# Altium schematic sheet Single_Supply_ADC_V1.1.SchDoc(Single_Supply_ADC_V1.1)
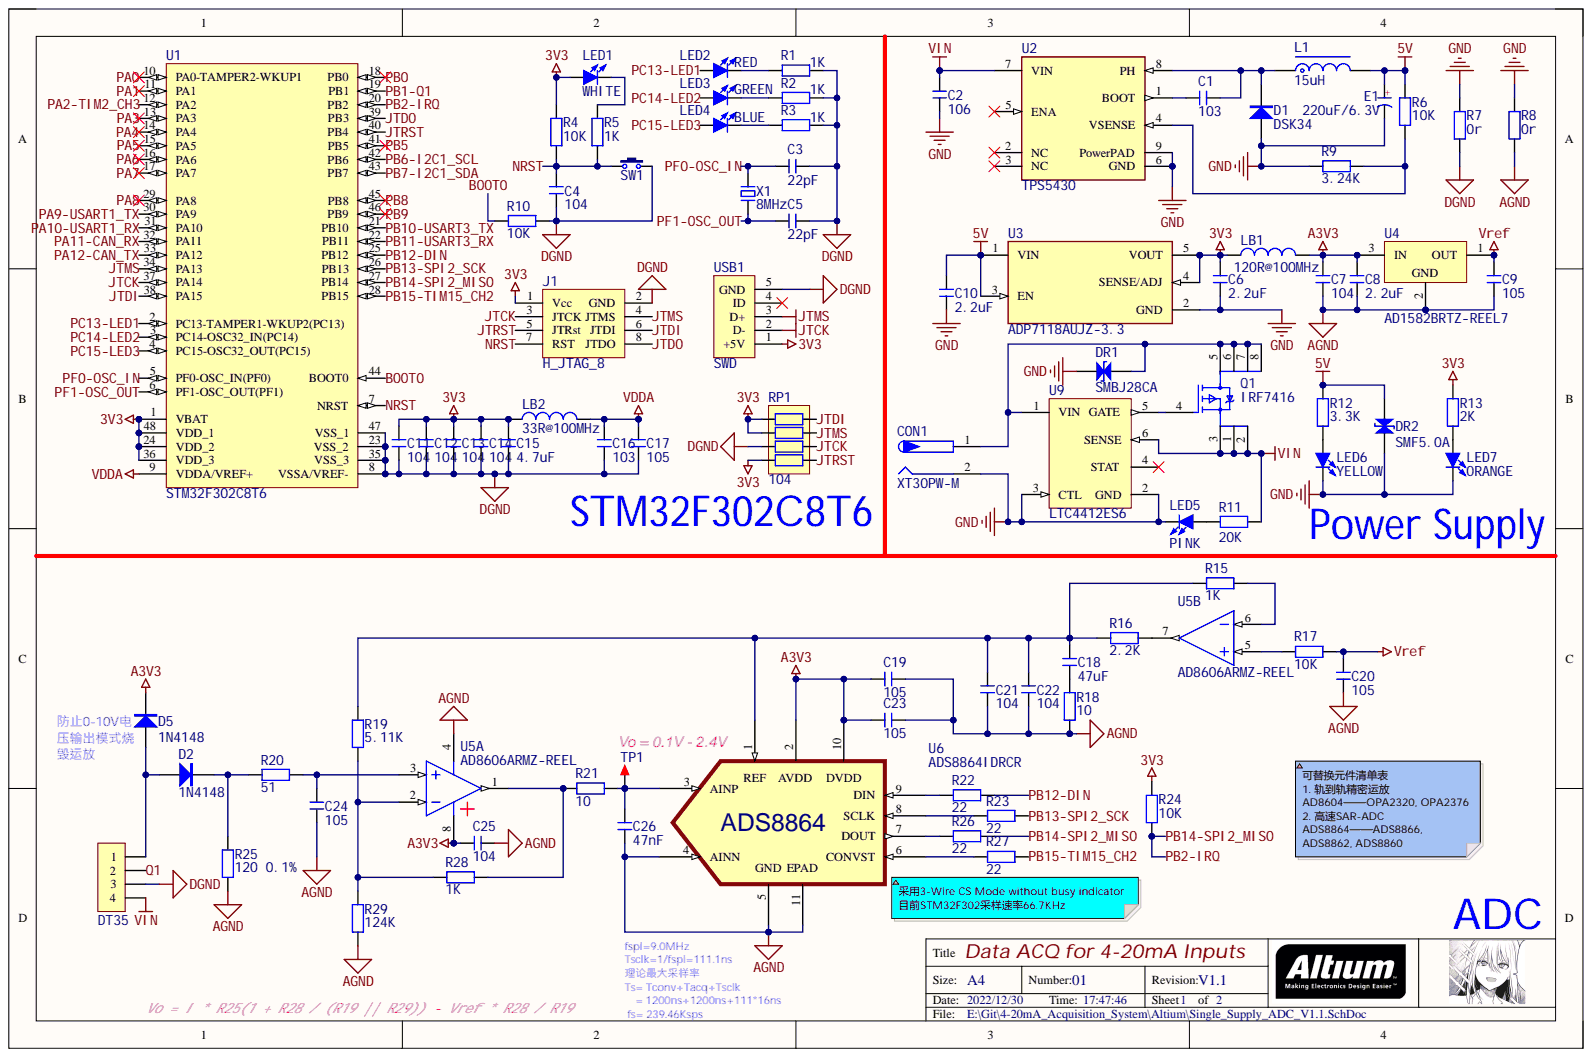
[diagram p0: Single_Supply_ADC_V1.1.SchDoc]

## Parts
C1  103  [C 0603_L]
C2  106  [C 0805_L]
C3  22pF  [C 0603_L]
C4  104  [C 0603_L]
C5  22pF  [C 0603_L]
C6  2.2uF  [C 0603_L]
C7  104  [C 0603_L]
C8  2.2uF  [C 0603_L]
C9  105  [C 0603_L]
C10  2.2uF  [C 0603_L]
C11  104  [C 0603_L]
C12  104  [C 0603_L]
C13  104  [C 0603_L]
C14  104  [C 0603_L]
C15  4.7uF  [C 0603_L]
C16  103  [C 0603_L]
C17  105  [C 0603_L]
C18  47uF  [C 0603_L]
C19  105  [C 0603_L]
C20  105  [C 0603_L]
C21  104  [C 0603_L]
C22  104  [C 0603_L]
C23  105  [C 0603_L]
C24  105  [C 0603_L]
C25  104  [C 0603_L]
C26  47nF  [C 0603_L]
CON1  XT30PW-M  [XT30PW-M]
D1  DSK34  [SOD-123]
D2  1N4148  [SOD-123]
D5  1N4148  [SOD-123]
DR1  SMBJ28CA  [SMB]
DR2  SMF5.0A  [SOD-323]
DT35  HDR-1X4  [XH2.54-LS-4P]  (value not drawn)
E1  220uF/6.3V  [CD B(3528)]
J1  H_JTAG_8  [IDC2.54-LI-2x4P]
L1  15uH  [NR4020]
LB1  120R@100MHz  [FB 0603_L]
LB2  33R@100MHz  [FB 0603_L]
LED1  WHITE  [LED 0603W]
LED2  RED  [LED 0603R]
LED3  GREEN  [LED 0603G]
LED4  BLUE  [LED 0603B]
LED5  PINK  [LED 0603R]
LED6  YELLOW  [LED 0603R]
LED7  ORANGE  [LED 0603R]
Q1  IRF7416  [SOP8_L]
R1  1K  [R 0603_L]
R2  1K  [R 0603_L]
R3  1K  [R 0603_L]
R4  10K  [R 0603_L]
R5  1K  [R 0603_L]
R6  10K  [R 0603_L]
R7  0r  [R 0603_L]
R8  0r  [R 0603_L]
R9  3.24K  [R 0603_L]
R10  10K  [R 0603_L]
R11  20K  [R 0603_L]
R12  3.3K  [R 0603_L]
R13  2K  [R 0603_L]
R15  1K  [R 0603_L]
R16  2.2K  [R 0603_L]
R17  10K  [R 0603_L]
R18  10  [R 0603_L]
R19  5.11K  [R 0603_L]
R20  51  [R 0603_L]
R21  10  [R 0603_L]
R22  22  [R 0603_L]
R23  22  [R 0603_L]
R24  10K  [R 0603_L]
R25  120 0.1%  [R 0603_L]
R26  22  [R 0603_L]
R27  22  [R 0603_L]
R28  1K  [R 0603_L]
R29  124K  [R 0603_L]
RP1  104  [RCA-8P4R-0603]
SW1  6x6x5  [TS 2PIN 6x6x4.8]  (value not drawn)
TP1  (no value)  [TEST 1]
U1  STM32F302C8T6  [LQFP48 7X7_L]
U2  TPS5430  [Texas_Instruments_DDA0008J_IPC_C]
U3  ADP7118AUJZ-3.3  [Analog_Devices_Inc_UJ-5_IPC_C]
U4  AD1582BRTZ-REEL7  [SOT23-3L]
U5  AD8606ARMZ-REEL  [RM_8_ADI-L]  (parts A,B)
U6  ADS8864IDRCR  [DRC10_TEX]
U9  LTC4412ES6  [Texas_Instruments_DDC0006A_0]
USB1  SWD  [USB-MICRO_C]
X1  8MHz  [OSC 5032-2P]

## Nets
3V3: C6.1 C11.2 C12.2 C13.2 C14.2 C15.2 J1.1(Vcc) LB1.1 LB2.1 LED1.1 R4.2 R13.2 R24.2 RP1.5 RP1.6 RP1.8 U1.1(VBAT) U1.24(VDD_2) U1.36(VDD_3) U1.48(VDD_1) U3.4(SENSE/ADJ) U3.5(VOUT) USB1.1(+5V)
5V: C10.1 DR2.2 E1.1 L1.2 R6.2 R12.2 U3.1(VIN) U3.3(EN)
A3V3: C7.1 C8.1 C19.2 C23.2 C25.2 D5.2 LB1.2 U4.3 U5.8 U6.2 U6.10
AGND: C7.2 C8.2 C9.2 C19.1 C20.1 C21.1 C22.1 C23.1 C24.1 C25.1 C26.2 R8.2 R18.1 R25.1 R29.1 U4.2 U5.4 U6.4(AINN) U6.5 U6.11
DGND: C3.1 C4.1 C5.1 C11.1 C12.1 C13.1 C14.1 C15.1 C16.1 C17.1 DT35.3 J1.2(GND) R1.2 R2.2 R3.2 R7.2 R10.1 RP1.7 SW1.2 U1.8(VSSA/VREF-) U1.23(VSS_2) U1.35(VSS_3) U1.47(VSS_1) USB1.5(GND)
GND: C2.1 C6.2 C10.2 CON1.2 D1.1 DR1.2 DR2.1 E1.2 LED5.2 LED6.2 LED7.2 R7.1 R8.1 R9.2 U2.6(GND) U2.9(PowerPAD) U3.2(GND) U9.2(GND) U9.3(CTL)
VDDA: C16.2 C17.2 LB2.2 U1.9(VDDA/VREF+)
VIN: C2.2 DT35.4 Q1.1 Q1.2 Q1.3 R11.1 U2.7(VIN) U9.6(SENSE)
Vref: C9.1 C20.2 R17.1 U4.1
BOOT0: R10.2 U1.44(BOOT0)
JTCK: J1.3(JTCK) RP1.2 U1.37(PA14) USB1.2(D-)
JTDI: J1.6(JTDI) RP1.4 U1.38(PA15)
JTDO: J1.8(JTDO) U1.39(PB3)
JTMS: J1.4(JTMS) RP1.3 U1.34(PA13) USB1.3(D+)
JTRST: J1.5(JTRst) RP1.1 U1.40(PB4)
NRST: C4.2 J1.7(RST) R4.1 R5.1 SW1.1 U1.7(NRST)
PA0: U1.10(PA0-TAMPER2-WKUP1)
PA1: U1.11(PA1)
PA2-TIM2_CH3: U1.12(PA2)
PA3: U1.13(PA3)
PA4: U1.14(PA4)
PA5: U1.15(PA5)
PA6: U1.16(PA6)
PA7: U1.17(PA7)
PA8: U1.29(PA8)
PA9-USART1_TX: U1.30(PA9)
PA10-USART1_RX: U1.31(PA10)
PA11-CAN_RX: U1.32(PA11)
PA12-CAN_TX: U1.33(PA12)
PB0: U1.18(PB0)
PB1-Q1: U1.19(PB1)
PB2-IRQ: R24.1 R26.1 U1.20(PB2) U1.27(PB14)
PB5: U1.41(PB5)
PB6-I2C1_SCL: U1.42(PB6)
PB7-I2C1_SDA: U1.43(PB7)
PB8: U1.45(PB8)
PB9: U1.46(PB9)
PB10-USART3_TX: U1.21(PB10)
PB11-USART3_RX: U1.22(PB11)
PB12-DIN: R22.1 U1.25(PB12)
PB13-SPI2_SCK: R23.1 U1.26(PB13)
PB15-TIM15_CH2: R27.1 U1.28(PB15)
PC13-LED1: LED2.1 U1.2(PC13-TAMPER1-WKUP2(PC13))
PC14-LED2: LED3.1 U1.3(PC14-OSC32_IN(PC14))
PC15-LED3: LED4.1 U1.4(PC15-OSC32_OUT(PC15))
PF0-OSC_IN: C3.2 U1.5(PF0-OSC_IN(PF0)) X1.2
PF1-OSC_OUT: C5.2 U1.6(PF1-OSC_OUT(PF1)) X1.1
Q1: DT35.2
NetC1_1: C1.1 D1.2 L1.1 U2.8(PH)
NetC1_2: C1.2 U2.1(BOOT)
NetC18_1: C18.1 R18.2
NetC18_2: C18.2 C21.2 C22.2 R15.2 R16.2 R19.2 U6.1
NetC24_2: C24.2 R20.1 U5.3
NetC26_1: C26.1 R21.1 TP1.1 U6.3(AINP)
NetCON1_1: CON1.1 DR1.1 Q1.5 Q1.6 Q1.7 Q1.8 U9.1(VIN)
NetD2_1: D2.1 D5.1 DT35.1
NetD2_2: D2.2 R20.2 R25.2
NetLED1_2: LED1.2 R5.2
NetLED2_2: LED2.2 R1.1
NetLED3_2: LED3.2 R2.1
NetLED4_2: LED4.2 R3.1
NetLED5_1: LED5.1 R11.2
NetLED6_1: LED6.1 R12.1
NetLED7_1: LED7.1 R13.1
NetQ1_4: Q1.4 U9.5(GATE)
NetR6_1: R6.1 R9.1 U2.4(VSENSE)
NetR15_1: R15.1 U5.6
NetR16_1: R16.1 U5.7
NetR17_2: R17.2 U5.5
NetR19_1: R19.1 R28.2 R29.2 U5.2
NetR21_2: R21.2 R28.1 U5.1
NetR22_2: R22.2 U6.9(DIN)
NetR23_2: R23.2 U6.8(SCLK)
NetR26_2: R26.2 U6.7(DOUT)
NetR27_2: R27.2 U6.6(CONVST)

Not connected: U2 2(NC) 3(NC) 5(ENA); U9 4(STAT); USB1 4(ID)

## Library data of the parts
C1-C26 = C: 无极性贴片电容; 材质: X7R; BOM名称: 无极性贴片电容; Component Kind: Standard; Library Name: 3.1   - 电阻-电容-电感.SchLib
CON1 = XT30PW-M: XT30PW-M PCB板专用卧式连接器，低温升阻燃插头; Component Kind: Standard; Library Name: AMASS.IntLib
D1 = 1N5819: 肖特基二极管; 属性: 40V/2A; BOM名称: 肖特基二极管; Component Kind: Standard; Library Name: 8.1   - 二极管-整流桥.SchLib
D2 D5 = 1N4148: 高速开关二极管; BOM名称: 高速开关二极管; Component Kind: Standard; Library Name: 8.1   - 二极管-整流桥.SchLib
DR1 DR2 = DR: 瞬态电压抑制二极管; BOM名称: 瞬态电压抑制二极管; Component Kind: Standard; Library Name: 8.1   - 二极管-整流桥.SchLib
DT35 = Header 4: 4P接插件; BOM名称: 4P接插件; Component Kind: Standard; Library Name: 4.6   - 接插件-脚距2.54.SchLib
E1 = C-CD: 贴片钽电容; BOM名称: 贴片钽电容; Component Kind: Standard; Library Name: 3.1   - 电阻-电容-电感.SchLib
J1 = H_JTAG_8: 8脚JTAG下载接口; Component Kind: Standard; Library Name: 接插件.SCHLIB
L1 = L-NR: NR磁胶电感; BOM名称: NR磁胶电感; Component Kind: Standard; Library Name: 3.1   - 电阻-电容-电感.SchLib
LB1 LB2 = LB: 磁珠; BOM名称: 磁珠; Component Kind: Standard; Library Name: 3.1   - 电阻-电容-电感.SchLib
LED1-LED7 = LED-SMD: 贴片LED; BOM名称: 贴片LED; Component Kind: Standard; Library Name: 9.1   - LED.SchLib
Q1 = IRF7416TRPBF: Mosfet Transistor, P Channel, -10 A, -30 V, 20 Mohm, 10 V, -1 V; Component Kind: Standard; Library Name: MOS.IntLib
R1-R13 R15-R29 = R: 贴片电阻; BOM名称: 贴片电阻; Component Kind: Standard; Library Name: 3.1   - 电阻-电容-电感.SchLib
RP1 = R-8P4R: 贴片网络排阻; BOM名称: 贴片网络排阻; Component Kind: Standard; Library Name: 3.1   - 电阻-电容-电感.SchLib
SW1 = TSW SMD-2Pin-6x6: 2脚贴片6x6无声硅胶轻触开关; BOM名称: 2脚轻触开关; Component Kind: Standard; Library Name: KEY.IntLib
TP1 = TEST: 测试点; BOM名称: 测试点; Component Kind: Standard; Library Name: 15.1 - 未分类器件.SchLib
U1 = STM32F302C8T6: ARM Cortex-M4 32-bit MCU, 64 KB Flash, 16 KB Internal RAM, 37 I/Os, 48-Pin LQFP, -40 to 85 degC, Tray; D/A Converter: 1; FLASH Size (Prog) (kB): 64; I/Os (High Current): 37; Internal RAM Size (kB): 16; Operating Frequency(F) (Processor speed) (MHz): 72; Supply Voltage(Vcc) min (V): 2; Component Kind: Standard; ComponentLink1Description: Manufacturer URL; ComponentLink1URL: http://www.st.com/; ComponentLink2Description: Datasheet; ComponentLink2URL: http://www.st.com/st-web-ui/static/active/en/resource/technical/document/datasheet/DM00093333.pdf; Core: ARM Cortex-M4; DatasheetVersion: Rev. 5; Mounting Technology: Surface Mount; Package Description: 48-Pin Low Profile Quad Flat Package, Body 7 x 7 mm, Pitch 0.5 mm; Package Reference: LQFP48; Packing: Tray; PartNumber: STM32F302C8T6; Library Name: 高级IC.IntLib
U2 = TPS5430DDA: 5.5V to 36V Input, 3A, 500kHz Step-Down Converter 8-SO PowerPAD 0 to 0; Component Kind: Standard; Library Name: 高级IC.IntLib
U3 = ADP7118AUJZ-3.3-R7: 20 V, 200 mA, Low Noise,  CMOS LDO Linear Regulator; Component Kind: Standard; Library Name: 高级IC.IntLib
U4 = AD1582BRTZ-REEL7: Micropower, Precision Series Mode Voltage Reference, 2.5 V Output, 2.7 to 12 V Supply, Industrial, 3-pin SOT23 (RT-3), Reel; Manufacturer: Analog Devices; Ref Out TC (ppm/C): 18; Vout: 2.5V; Code_JEDEC: TO-236-AB; Component Kind: Standard; ComponentLink1Description: Manufacturer URL; ComponentLink1URL: http://www.analog.com/en/index.html; ComponentLink2Description: Datasheet; ComponentLink2URL: http://www.analog.com/static/imported-files/data_sheets/AD1582_1583_1584_1585.pdf; ComponentLink3Description: Package Specification; ComponentLink3URL: http:/www.analog.com/static/imported-files/packages/PKG_PDF/SOT-23-3 (RT)/RT_3.pdf; ComponentLink4Description: URL Link1; ComponentLink4URL: Product URL:http://www.analog.com/en/special-linear-functions/voltage-references/ad1582/products/product.html; DatasheetVersion: Rev. I; Mounting Technology: Surface Mount; Operating Temp Range: -40 to 125 degC; PackageDescription: 3-Pin Small Outline Transistor Package, Body 2.9 x 1.3 mm, Pitch 0.96 mm; PackageReference: RT-3; PackageVersion: 011909-C, 02/2009; Packing: Reel; PartNumber: AD1582BRTZ-REEL7; Library Name: 高级IC.IntLib
U5 = AD8606ARMZ-REEL: Double Channel Wide Bandwidth Operational Amplifier, 10 MHz BW, 5 V/us SR, Industrial, 8-pin SOP (RM-8), Reel; Generic Part Number: AD8606; Manufacturer: Analog Devices; Amplifiers Per Package: 2; Input Bias Current: 200fA; Input Offset Voltage: 20uV; Iq/Amp: 1.2mA; Slew Rate: 5V/us; Small Signal Bandwidth: 10MHz; V Span Max: 6V; V Span Min: 2.7V; Code_JEDEC: MO-187-AA; Component Kind: Standard; ComponentLink1Description: Manufacturer URL; ComponentLink1URL: http://www.analog.com/en/index.html; ComponentLink2Description: Datasheet; ComponentLink2URL: http://www.analog.com/static/imported-files/data_sheets/AD8605_8606_8608.pdf; ComponentLink3Description: Package Specification; ComponentLink3URL: http://www.analog.com/static/imported-files/packages/PKG_PDF/MSOP%28RM%29/RM_8.pdf; ComponentLink4Description: URL Link1; ComponentLink4URL: Reference Circuit:http://www.analog.com/en/all-operational-amplifiers-op-amps/operational-amplifiers-op-amps/ad8606/products/product.html#product-recommendations; DatasheetVersion: Rev. L; Mounting Technology: Surface Mount; PackageDescription: 8-Pin Mini Small Outline Package, Body 3 x 3 mm, Pitch 0.65 mm; PackageReference: RM-8; PartNumber: AD8606ARMZ-REEL; Library Name: 高级IC.IntLib
U6 = ADS8864IDRCR: 16-Bit, 400-kSPS, 1-Ch SAR ADC with single-ended input, SPI interface and daisy-chain 10-VSON -40 to 85; Mfr_Name: Texas Instruments; Component Kind: Standard; Manufacturer_Part_Number: ADS8864IDRCR; RefDes: RefDes; Type: DEV; Copyright: Copyright (C) 2022 Ultra Librarian. All rights reserved.; Library Name: 高级IC.IntLib
U9 = LTC4412ES6#TRPBF: Low Loss PowerPath(TM) Controller, 2.5 to 28 V Vin, 6-pin SOT23 (S6-6), -40 to 85 degC, Pb-Free, Tape and Reel; Manufacturer: Linear Technology; Ideal Diode: Single, External, P-Channel; Code_JEDEC: MO-193; Component Kind: Standard; ComponentLink1Description: Manufacturer URL; ComponentLink1URL: http://www.linear.com/; ComponentLink2Description: Datasheet; ComponentLink2URL: http://cds.linear.com/docs/en/datasheet/4412fa.pdf; ComponentLink3Description: Package Specification; ComponentLink3URL: http://cds.linear.com/docs/en/packaging/SOT_6_05-08-1636.pdf; Mounting Technology: Surface Mount; PackageDescription: 6-Pin Plastic TSOT-23, Body 2.9 x 1.63 mm, Pitch 0.95 mm; PackageReference: S6-6; PackageVersion: Jun-10; Packing: Tape and Reel; PartNumber: LTC4412ES6#TRPBF; RoHS: TRUE; Library Name: 高级IC.IntLib
USB1 = USB-5P: 微型USB母座; BOM名称: 微型USB母座; Component Kind: Standard; Library Name: 4.1   - 接口类.SchLib
X1 = XTAL-2P: 2脚无源脚晶振; 负载电容: CL20pF; BOM名称: 2脚无源脚晶振; Component Kind: Standard; Library Name: 10.1 - 保险丝-晶振.SchLib
